# Altium schematic sheet connectors.SchDoc(connectors)
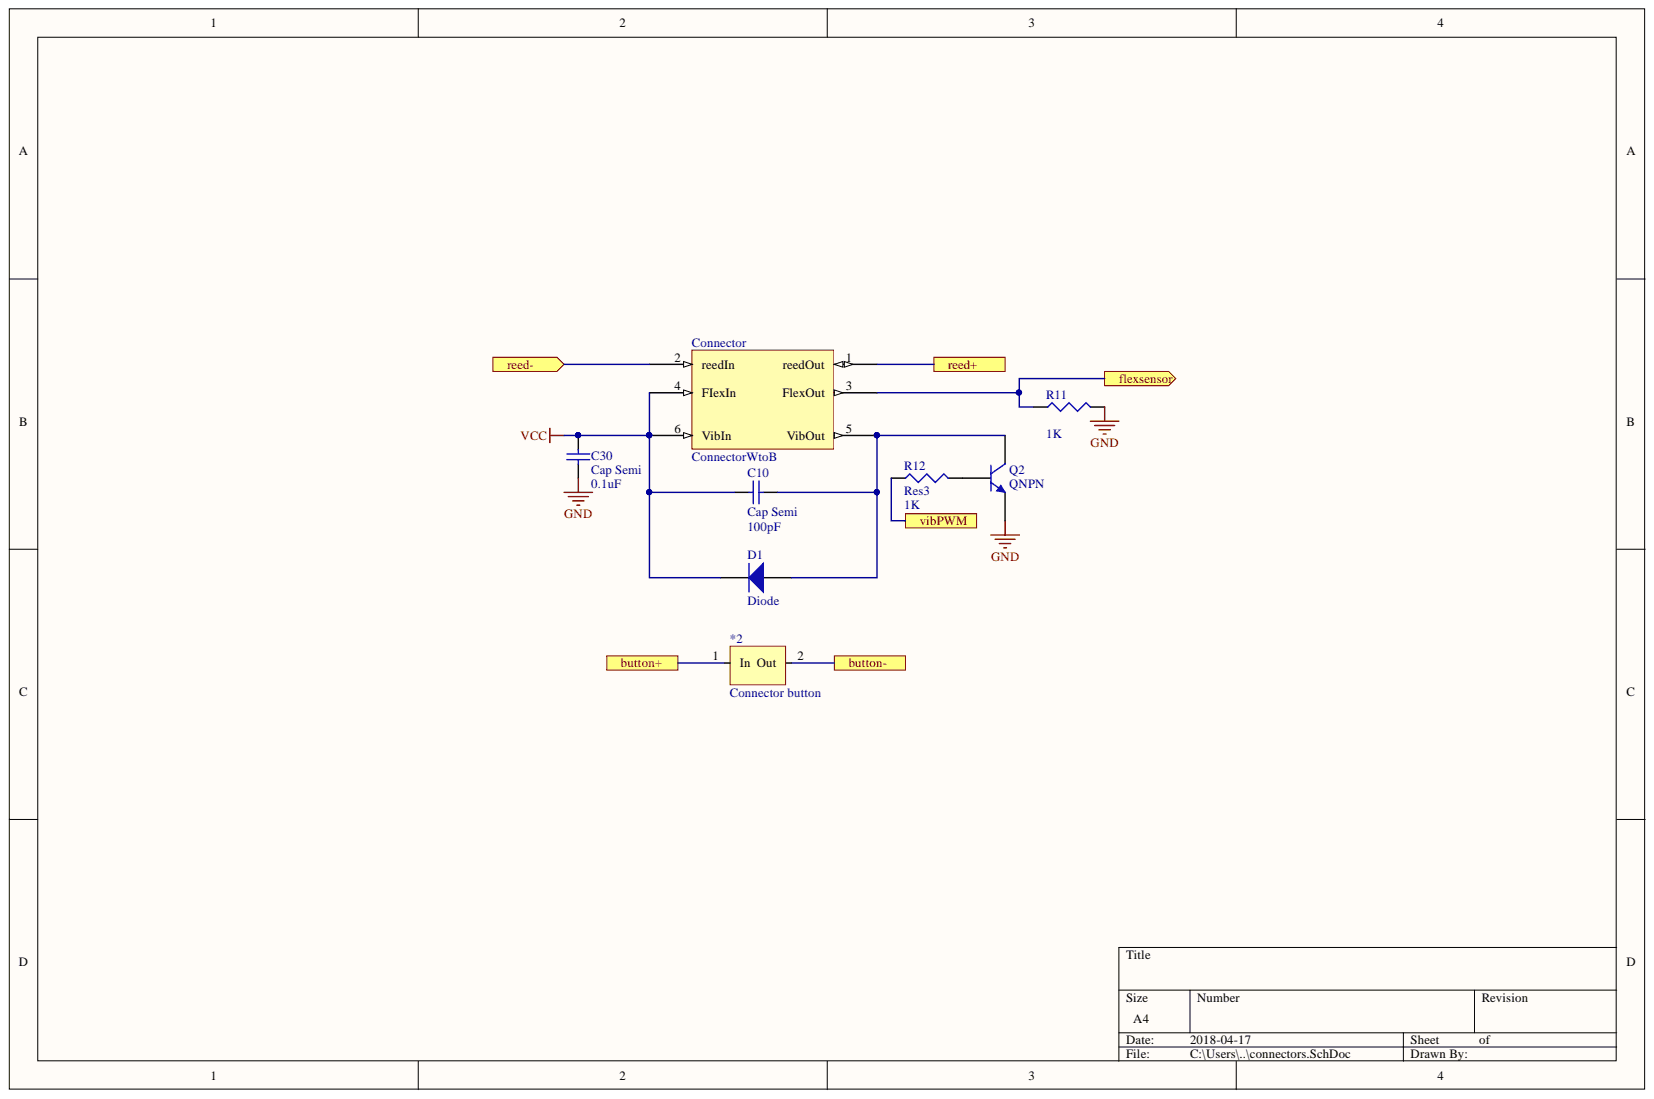
[diagram p0: connectors.SchDoc]

## Parts
*2  Connector button  [Button_connector]
C10  Cap Semi  value 100pF  [1608[0603]]
C30  Cap Semi  value 0.1uF  [1608[0603]]
Connector  ConnectorWtoB  [Connector_wire_to_PCB]
D1  Diode  [SMC]
Q2  QNPN  [SOT-23B_N]
R11  value 1K  [J1-0603]  (hidden comment: Res3)
R12  Res3  value 1K  [J1-0603]

## Ports
button+, button-, flexsensor, reed+, reed-, vibPWM

## Nets
GND: C30.1 Q2.3 R11.2
VCC: C10.1 C30.2 Connector.4(FIexIn) Connector.6(VibIn) D1.2
flexsensor: Connector.3(FlexOut) R11.1 ; port flexsensor
vibPWM: R12.1 ; port vibPWM
Net*2_1: *2.1
Net*2_2: *2.2
NetC10_2: C10.2 Connector.5(VibOut) D1.1 Q2.1
NetConnector_1: Connector.1(reedOut)
NetConnector_2: Connector.2(reedIn)
NetQ2_2: Q2.2 R12.2

## Library data of the parts
C10 C30 = Cap Semi: Capacitor (Semiconductor SIM Model); Simulation: CAP; Signal Integrity: Cap Semi; Published: 8-Jun-2000; PackageReference: C1206; PackageDescription: Chip Capacitor; Library Name: Miscellaneous Devices.IntLib; LatestRevisionNote: IPC-7351 Footprint Added.; LatestRevisionDate: 29-May-2009; Component Kind: Standard; Publisher: Altium Limited
D1 = Diode: Default Diode; Simulation: DIODE; Signal Integrity: Diode; Published: 8-Jun-2000; PackageVersion: Sep-1996; PackageReference: SMC; PackageDescription: DO-214-AB/SMC; 2 C-Bend Leads; Body 7.9 x 5.9 mm, inc. leads (LxW); Library Name: Miscellaneous Devices.IntLib; LatestRevisionNote: Re-released for DXP Platform.; LatestRevisionDate: 17-Jul-2002; Component Kind: Standard; Code_JEDEC: DO-214-AB; Publisher: Altium Limited
Q2 = QNPN: NPN Bipolar Transistor; Simulation: QNPN; Signal Integrity: NPN; Published: 8-Jun-2000; PackageVersion: Aug-1999; PackageReference: SOT-23B; PackageDescription: 3-Pin SOT-23 Package 0.95 mm Pitch, 2.4 mm Lead Span; Library Name: Miscellaneous Devices.IntLib; LatestRevisionNote: IPC-7351 Footprint Added.; LatestRevisionDate: 29-May-2009; Component Kind: Standard; Publisher: Altium Limited
R11 R12 = Res3: Resistor; Simulation: RESISTOR; Signal Integrity: Res; Published: 8-Jun-2000; PackageReference: J1-0603; PackageDescription: Chip Resistor; Library Name: Miscellaneous Devices.IntLib; LatestRevisionNote: IPC-7351 Footprint Added.; LatestRevisionDate: 29-May-2009; Component Kind: Standard; Publisher: Altium Limited
*2 = Connector button: Component Kind: Standard; Library Name: HGCDLibrary.IntLib
Connector = ConnectorWtoB: Component Kind: Standard; Library Name: HGCDLibrary.IntLib
